# Altium schematic sheet CM001_V4_5.SchDoc(CM001_V4_5)
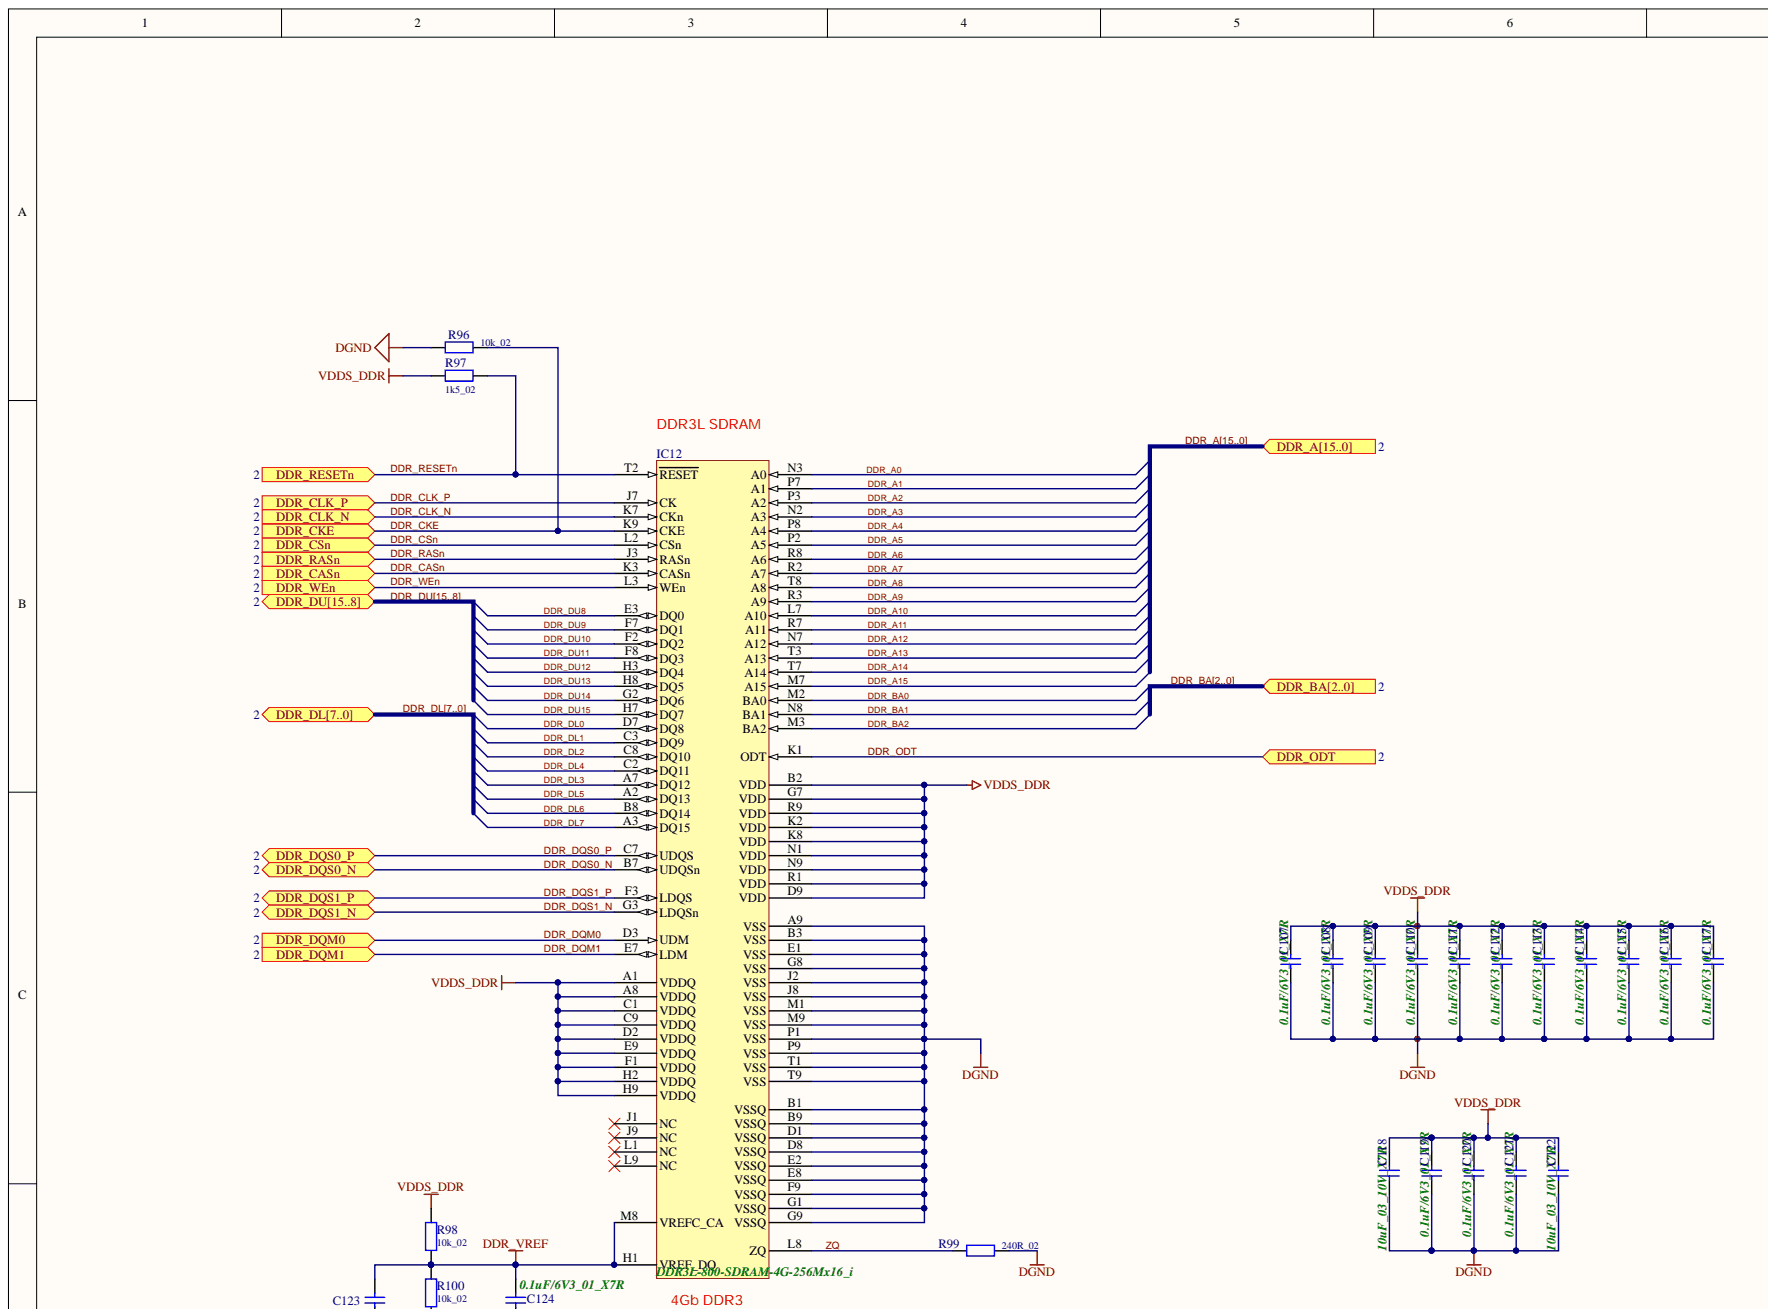
[diagram p0: CM001_V4_5.SchDoc]

## Parts
C107  0.1uF/6V3_01_X7R  [CC0603-0201]
C107
C108  0.1uF/6V3_01_X7R  [CC0603-0201]
C108
C109  0.1uF/6V3_01_X7R  [CC0603-0201]
C109
C110  0.1uF/6V3_01_X7R  [CC0603-0201]
C110
C111  0.1uF/6V3_01_X7R  [CC0603-0201]
C111
C112  0.1uF/6V3_01_X7R  [CC0603-0201]
C112
C113  0.1uF/6V3_01_X7R  [CC0603-0201]
C113
C114  0.1uF/6V3_01_X7R  [CC0603-0201]
C114
C115  0.1uF/6V3_01_X7R  [CC0603-0201]
C115
C116  0.1uF/6V3_01_X7R  [CC0603-0201]
C116
C117  0.1uF/6V3_01_X7R  [CC0603-0201]
C117
C118  10uF_03_10V_X7R  [CC1608-0603]
C118  10uF_03_10V_X7R  [CC1608-0603]
C119  0.1uF/6V3_01_X7R  [CC0603-0201]
C119
C120  0.1uF/6V3_01_X7R  [CC0603-0201]
C120
C121  0.1uF/6V3_01_X7R  [CC0603-0201]
C121
C122  10uF_03_10V_X7R  [CC1608-0603]
C122  10uF_03_10V_X7R  [CC1608-0603]
C123  1nF/50V_02  [CC1005-0402]  (value not drawn)
C123  1nF/50V_02  [CC1005-0402]  (value not drawn)
C124  0.1uF/6V3_01_X7R  [CC0603-0201]
C124
IC12  DDR3L-800-SDRAM-4G-256Mx16_i  [BGA96_T9_P8_9X14]
R96  10k_02  [CR1005-0402]
R97  1k5_02  [CR1005-0402]
R98  10k_02  [CR1005-0402]
R99  240R_02  [CR1005-0402]
R100  10k_02  [CR1005-0402]

## Ports
DDR_A0, DDR_A1, DDR_A2, DDR_A3, DDR_A4, DDR_A5, DDR_A6, DDR_A7, DDR_A8, DDR_A9, DDR_A10, DDR_A11, DDR_A12, DDR_A13, DDR_A14, DDR_A15, DDR_A[15..0], DDR_BA0, DDR_BA1, DDR_BA2, DDR_BA[2..0], DDR_CASn, DDR_CKE, DDR_CLK_N, DDR_CLK_P, DDR_CSn, DDR_DL0, DDR_DL1, DDR_DL2, DDR_DL3, DDR_DL4, DDR_DL5, DDR_DL6, DDR_DL7, DDR_DL[7..0], DDR_DQM0, DDR_DQM1, DDR_DQS0_N, DDR_DQS0_P, DDR_DQS1_N, DDR_DQS1_P, DDR_DU8, DDR_DU9, DDR_DU10, DDR_DU11, DDR_DU12, DDR_DU13, DDR_DU14, DDR_DU15, DDR_DU[15..8], DDR_ODT, DDR_RASn, DDR_RESETn, DDR_WEn

## Nets
DDR_VREF: C123.2 C124.2 IC12.H1 IC12.M8 R98.1 R100.1
DGND: C107.1 C108.1 C109.1 C110.1 C111.1 C112.1 C113.1 C114.1 C115.1 C116.1 C117.1 C118.1 C119.1 C120.1 C121.1 C122.1 C123.1 C124.1 IC12.A9 IC12.B1 IC12.B3 IC12.B9 IC12.D1 IC12.D8 IC12.E1 IC12.E2 IC12.E8 IC12.F9 IC12.G1 IC12.G8 IC12.G9 IC12.J2 IC12.J8 IC12.M1 IC12.M9 IC12.P1 IC12.P9 IC12.T1 IC12.T9 R96.2 R99.2 R100.2
VDDS_DDR: C107.2 C108.2 C109.2 C110.2 C111.2 C112.2 C113.2 C114.2 C115.2 C116.2 C117.2 C118.2 C119.2 C120.2 C121.2 C122.2 IC12.A1 IC12.A8 IC12.B2 IC12.C1 IC12.C9 IC12.D2 IC12.D9 IC12.E9 IC12.F1 IC12.G7 IC12.H2 IC12.H9 IC12.K2 IC12.K8 IC12.N1 IC12.N9 IC12.R1 IC12.R9 R97.2 R98.2
DDR_A0: IC12.N3 ; port DDR_A[15..0]
DDR_A1: IC12.P7 ; port DDR_A[15..0]
DDR_A2: IC12.P3 ; port DDR_A[15..0]
DDR_A3: IC12.N2 ; port DDR_A[15..0]
DDR_A4: IC12.P8 ; port DDR_A[15..0]
DDR_A5: IC12.P2 ; port DDR_A[15..0]
DDR_A6: IC12.R8 ; port DDR_A[15..0]
DDR_A7: IC12.R2 ; port DDR_A[15..0]
DDR_A8: IC12.T8 ; port DDR_A[15..0]
DDR_A9: IC12.R3 ; port DDR_A[15..0]
DDR_A10: IC12.L7 ; port DDR_A[15..0]
DDR_A11: IC12.R7 ; port DDR_A[15..0]
DDR_A12: IC12.N7 ; port DDR_A[15..0]
DDR_A13: IC12.T3 ; port DDR_A[15..0]
DDR_A14: IC12.T7 ; port DDR_A[15..0]
DDR_A15: IC12.M7 ; port DDR_A[15..0]
DDR_BA0: IC12.M2 ; port DDR_BA[2..0]
DDR_BA1: IC12.N8 ; port DDR_BA[2..0]
DDR_BA2: IC12.M3 ; port DDR_BA[2..0]
DDR_CASn: IC12.K3 ; port DDR_CASn
DDR_CKE: IC12.K9 R96.1 ; port DDR_CKE
DDR_CLK_N: IC12.K7 ; port DDR_CLK_N
DDR_CLK_P: IC12.J7 ; port DDR_CLK_P
DDR_CSn: IC12.L2 ; port DDR_CSn
DDR_DL0: IC12.D7 ; port DDR_DL[7..0]
DDR_DL1: IC12.C3 ; port DDR_DL[7..0]
DDR_DL2: IC12.C8 ; port DDR_DL[7..0]
DDR_DL3: IC12.A7 ; port DDR_DL[7..0]
DDR_DL4: IC12.C2 ; port DDR_DL[7..0]
DDR_DL5: IC12.A2 ; port DDR_DL[7..0]
DDR_DL6: IC12.B8 ; port DDR_DL[7..0]
DDR_DL7: IC12.A3 ; port DDR_DL[7..0]
DDR_DQM0: IC12.D3 ; port DDR_DQM0
DDR_DQM1: IC12.E7 ; port DDR_DQM1
DDR_DQS0_N: IC12.B7 ; port DDR_DQS0_N
DDR_DQS0_P: IC12.C7 ; port DDR_DQS0_P
DDR_DQS1_N: IC12.G3 ; port DDR_DQS1_N
DDR_DQS1_P: IC12.F3 ; port DDR_DQS1_P
DDR_DU8: IC12.E3 ; port DDR_DU[15..8]
DDR_DU9: IC12.F7 ; port DDR_DU[15..8]
DDR_DU10: IC12.F2 ; port DDR_DU[15..8]
DDR_DU11: IC12.F8 ; port DDR_DU[15..8]
DDR_DU12: IC12.H3 ; port DDR_DU[15..8]
DDR_DU13: IC12.H8 ; port DDR_DU[15..8]
DDR_DU14: IC12.G2 ; port DDR_DU[15..8]
DDR_DU15: IC12.H7 ; port DDR_DU[15..8]
DDR_ODT: IC12.K1 ; port DDR_ODT
DDR_RASn: IC12.J3 ; port DDR_RASn
DDR_RESETn: IC12.T2 R97.1 ; port DDR_RESETn
DDR_WEn: IC12.L3 ; port DDR_WEn
ZQ: IC12.L8 R99.1

Not connected: IC12 J1 J9 L1 L9

## Library data of the parts
C107-C117 C119-C121 C124 = C0.1uF/6V3_01_X7R: Capacitor 0201 6,3V X7R; S/T: SMD; Hersteller: any; erstellt von: Finke; Art-Nr.: 08.0002.0___; Produktbeispiel: Gudeco: muRata: GRM033R70J103JA01D; Value: 0.1uF/6V3_01_X7R; Supplier Part Number 2: 8USl_ZajxFVxkJsfRx-2TMMxBpVDD3GHQuOpPGq7oYPTEIlO4Ffw3x4_A6bjf4Mm; Supplier Part Number 1: 490-3167-6-ND; Supplier 2: Arrow; Supplier 1: Digi-Key; Component Kind: Standard; Bestellbezeichnung: *; Library Name: C_ies_Integrated_Library.IntLib
C118 C122 = C10uF_03_10V_X7R: Capacitor 0603 10uF 10V X7R; S/T: SMD; erstellt von: MIE; Art-Nr.: 08.0001.0___; Hinweis: KRU Artikelnummer gelöscht; Value: 10uF_03_10V_X7R; Simulation: CAP; Signal Integrity: Cap; Revision: July-2002: Re-released for DXP Platform.; Hersteller: *; Component Kind: Standard; Bestellbezeichnung: *; Library Name: C_ies_Integrated_Library.IntLib
C118 C122 = C10uF_03_10V_X7R: Capacitor 0603 10uF 10V X7R; S/T: SMD; Art-Nr.: 08.0001.0___; Hinweis: KRU Artikelnummer gelöscht; Value: 10uF_03_10V_X7R; Simulation: CAP; Signal Integrity: Cap; Hersteller: *; Component Kind: Standard; Bestellbezeichnung: *; Library Name: C_ies_Integrated_Library.IntLib
C123 = C1nF/50V_02: Capacitor 0402 X7R 50V; S/T: SMD; Erstellt von: Krüger; Art-Nr.: 08.0002.0009; Value: 1nF/50V_02; Lieferant: *; Hinweis: *; Hersteller1: *; Hersteller: *; Component Kind: Standard; Bestellbezeichnung1: *; Bestellbezeichnung: *; Library Name: C_ies_Integrated_Library.IntLib
C123 = C1nF/50V_02: Capacitor 0402 X7R 50V; S/T: SMD; erstellt von: Krüger; Art-Nr.: 08.0002.0009; Value: 1nF/50V_02; Component Kind: Standard; Bestellbezeichnung: *; Bestellbezeichnung1: *; Hersteller: *; Hersteller1: *; Hinweis: *; Lieferant: *; Library Name: C_ies_Integrated_Library.IntLib
IC12 = ICDDR3L-800-SDRAM-4G-256Mx16_i: DDR3L 800MHz SDRAM; 4Giga  256M x 16, industrial; S/T: SMD; Lieferant: Memphis; Hinweis: Bestellbezeichnung geändert (war ein eMMC )!; Hersteller: Intelligent Memory; erstellt von: FIN; Bestellbezeichnung: IM4G16D3FABG-125I; Art-Nr.: 04.0701.0145; Value: DDR3L-800-SDRAM-4G-256Mx16_i; ComponentLink1Description: Datenblatt; Component Kind: Standard; Library Name: IC_ies_Integrated_Library.IntLib; ComponentLink1URL: U:\IC_Integrierte Bauteile\Intelligent Memory\IM4G08-16D3FABG1.2-0821.pdf
R96 R98 R100 = R10k_02: Resistor; 0402; 1%; S/T: SMD; Art-Nr.: 09.0002.0004; Value: 10k_02; Supplier Part Number 2: Digi-Key - 311-10.0KLRCT-ND; Supplier Part Number 1: 311-10.0KLRCT-ND; Supplier 2: Octopart; Supplier 1: Digi-Key; Component Kind: Standard; Library Name: R_ies_Integrated_Library.IntLib
R97 = R1k5_02: Resistor; 0402; 1%; S/T: SMD; Art-Nr.: 09.0002.0028; Value: 1k5_02; Revision: July-2002: Re-released for DXP Platform.; Component Kind: Standard; Library Name: R_ies_Integrated_Library.IntLib
R99 = R240R_02: Resistor; 0402; 1%; S/T: SMD; Art-Nr.: 09.0002.0071; Value: 240R_02; Component Kind: Standard; Library Name: R_ies_Integrated_Library.IntLib
